# Altium schematic sheet SCM20260D-Connectors2.SchDoc(SCM20260D-Connectors2)
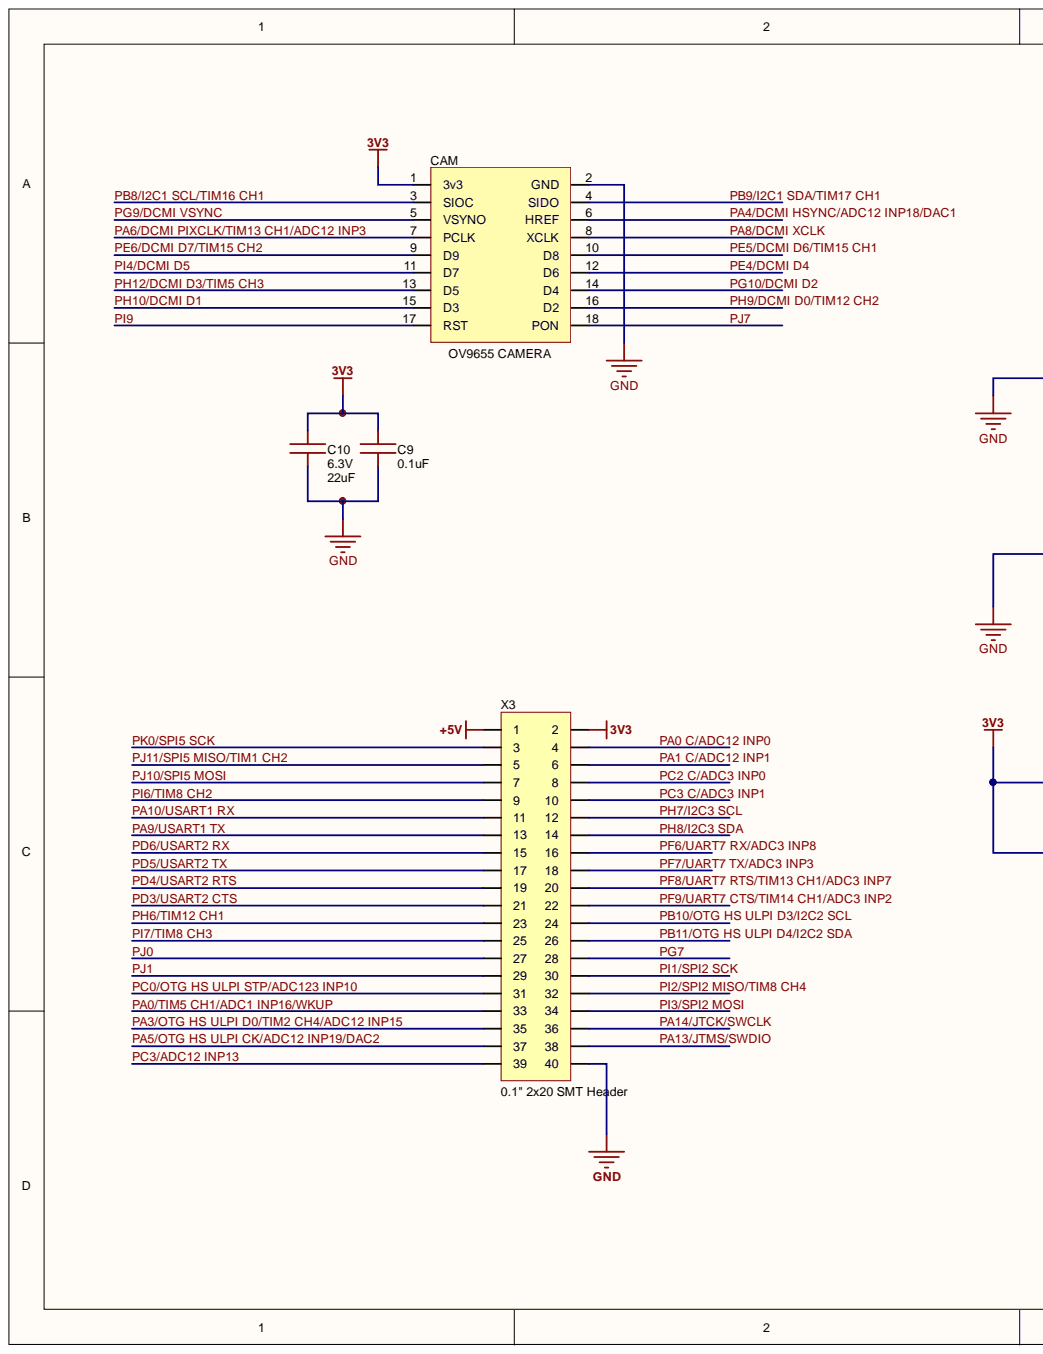
[diagram p0: SCM20260D-Connectors2.SchDoc, left]
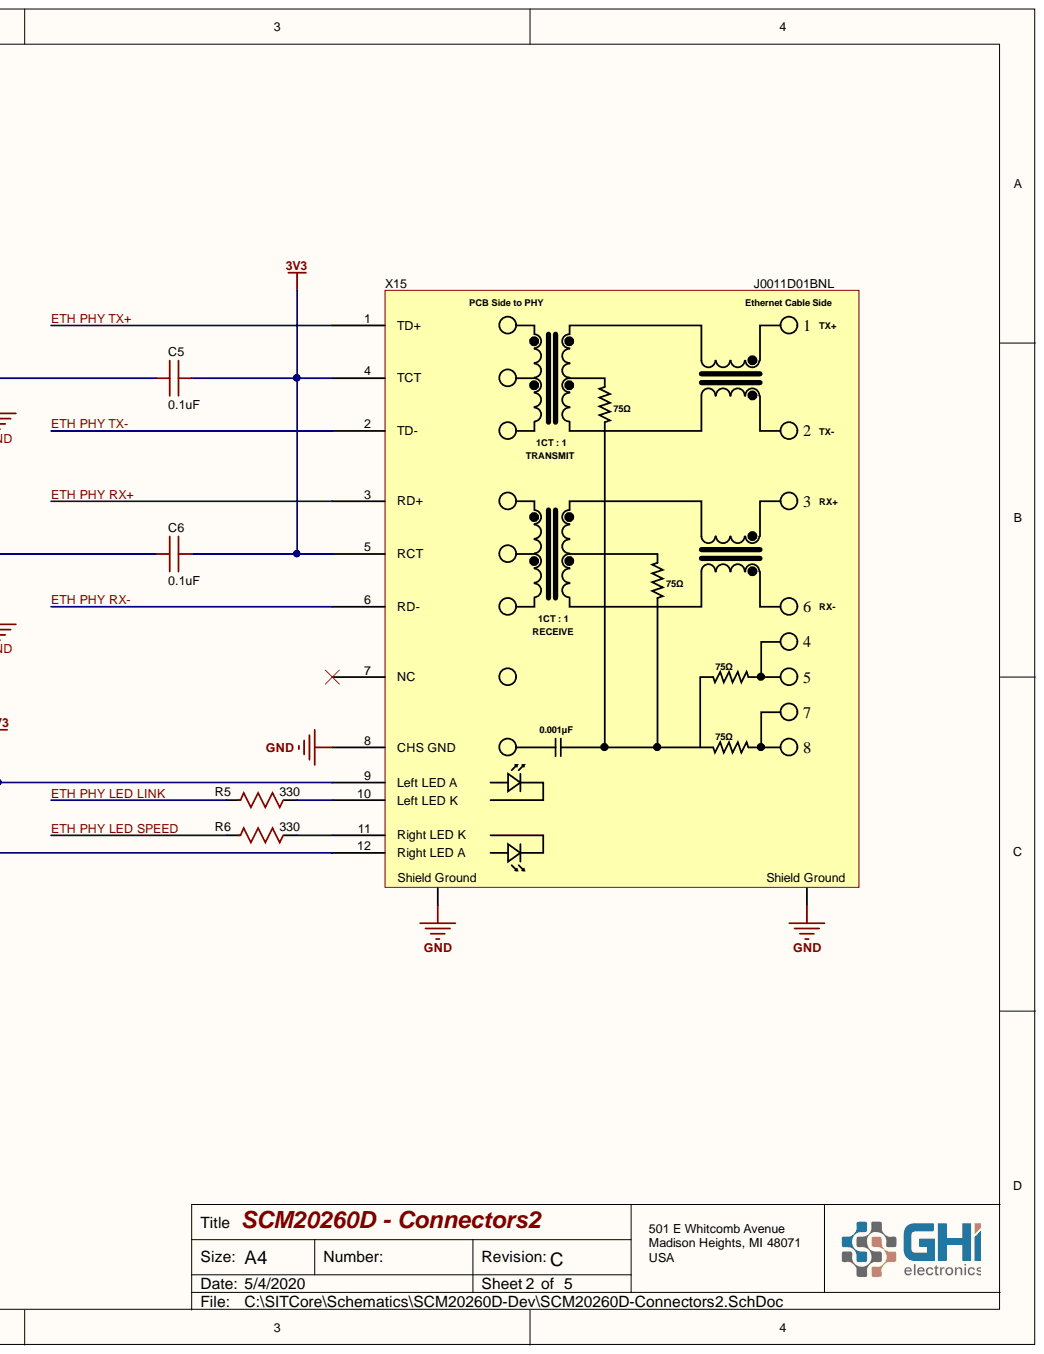
[diagram p1: SCM20260D-Connectors2.SchDoc, right]

## Parts
C5  value 0.1uF  [C0603 (1608 Metric)]  (hidden comment: 0603 Cap 0.1uF)
C6  value 0.1uF  [C0603 (1608 Metric)]  (hidden comment: 0603 Cap 0.1uF)
C9  value 0.1uF  [C0603 (1608 Metric)]  (hidden comment: 0603 Cap 0.1uF)
C10  value 22uF  Voltage: 6.3V  [C0805]  (hidden comment: 0805 Cap 22uF)
CAM  OV9655 CAMERA  value OV9655  [2X09]
R5  value 330  [R0603 (1608 Metric)]  (hidden comment: 0603 330)
R6  value 330  [R0603 (1608 Metric)]  (hidden comment: 0603 330)
X3  0.1" 2x20 SMT Header  [SMT 0.1" 2x20]
X15  J0011D01BNL  [J0A]

## Nets
3V3: C5.2 C6.2 C9.2 C10.2 CAM.1(3v3) X3.2 X15.4(TCT) X15.5(RCT) X15.9(Left_LED_A) X15.12(Right_LED_A)
+5V: X3.1
GND: C5.1 C6.1 C9.1 C10.1 CAM.2(GND) X3.40 X15.8(CHS_GND) X15.SG1 X15.SG2
ETH PHY LED LINK: R5.1
ETH PHY LED SPEED: R6.1
ETH PHY RX+: X15.3(RD+)
ETH PHY RX-: X15.6(RD-)
ETH PHY TX+: X15.1(TD+)
ETH PHY TX-: X15.2(TD-)
PA0 C/ADC12 INP0: X3.4
PA0/TIM5 CH1/ADC1 INP16/WKUP: X3.33
PA1 C/ADC12 INP1: X3.6
PA3/OTG HS ULPI D0/TIM2 CH4/ADC12 INP15: X3.35
PA4/DCMI HSYNC/ADC12 INP18/DAC1: CAM.6(HREF)
PA5/OTG HS ULPI CK/ADC12 INP19/DAC2: X3.37
PA6/DCMI PIXCLK/TIM13 CH1/ADC12 INP3: CAM.7(PCLK)
PA8/DCMI XCLK: CAM.8(XCLK)
PA9/USART1 TX: X3.13
PA10/USART1 RX: X3.11
PA13/JTMS/SWDIO: X3.38
PA14/JTCK/SWCLK: X3.36
PB8/I2C1 SCL/TIM16 CH1: CAM.3(SIOC)
PB9/I2C1 SDA/TIM17 CH1: CAM.4(SIDO)
PB10/OTG HS ULPI D3/I2C2 SCL: X3.24
PB11/OTG HS ULPI D4/I2C2 SDA: X3.26
PC0/OTG HS ULPI STP/ADC123 INP10: X3.31
PC2 C/ADC3 INP0: X3.8
PC3 C/ADC3 INP1: X3.10
PC3/ADC12 INP13: X3.39
PD3/USART2 CTS: X3.21
PD4/USART2 RTS: X3.19
PD5/USART2 TX: X3.17
PD6/USART2 RX: X3.15
PE4/DCMI D4: CAM.12(D6)
PE5/DCMI D6/TIM15 CH1: CAM.10(D8)
PE6/DCMI D7/TIM15 CH2: CAM.9(D9)
PF6/UART7 RX/ADC3 INP8: X3.16
PF7/UART7 TX/ADC3 INP3: X3.18
PF8/UART7 RTS/TIM13 CH1/ADC3 INP7: X3.20
PF9/UART7 CTS/TIM14 CH1/ADC3 INP2: X3.22
PG7: X3.28
PG9/DCMI VSYNC: CAM.5(VSYNO)
PG10/DCMI D2: CAM.14(D4)
PH6/TIM12 CH1: X3.23
PH7/I2C3 SCL: X3.12
PH8/I2C3 SDA: X3.14
PH9/DCMI D0/TIM12 CH2: CAM.16(D2)
PH10/DCMI D1: CAM.15(D3)
PH12/DCMI D3/TIM5 CH3: CAM.13(D5)
PI1/SPI2 SCK: X3.30
PI2/SPI2 MISO/TIM8 CH4: X3.32
PI3/SPI2 MOSI: X3.34
PI4/DCMI D5: CAM.11(D7)
PI6/TIM8 CH2: X3.9
PI7/TIM8 CH3: X3.25
PI9: CAM.17(RST)
PJ0: X3.27
PJ1: X3.29
PJ7: CAM.18(PON)
PJ10/SPI5 MOSI: X3.7
PJ11/SPI5 MISO/TIM1 CH2: X3.5
PK0/SPI5 SCK: X3.3
NetR5_2: R5.2 X15.10(Left_LED_K)
NetR6_2: R6.2 X15.11(Right_LED_K)

Not connected: X15 7(NC)

## Library data of the parts
C5 C6 C9 = 0603 Cap 0.1uF: 0.1uF ±5% 50V X7R 0603 Multilayer Ceramic Capacitors MLCC - SMD/SMT RoHS; Mfr.Part #: CL10B104JB8NNNC; Voltage: 50V; Tolerance: ±5%; Package: 0603; LCSC Part #: C24452; Digikey: 1276-1033-1-ND; Component Kind: Standard; Category: Ceramic; Capacitance: 0.1uF; Library Name: GHI-Passives.SchLib
C10 = 0805 Cap 22uF: 22uF ±20% 6.3V X5R 0805 Multilayer Ceramic Capacitors MLCC - SMD/SMT RoHS; Mfr.Part #: CL21A226MQCLRNC; Tolerance: ±20%; Package: 0805; LCSC Part #: C307530; Component Kind: Standard; Category: Ceramic; Capacitance: 22uF; Library Name: GHI-Passives.SchLib
R5 R6 = 0603 Res 330: 330 ±5% 1/10W ±100ppm/℃ 0603 Chip Resistor - Surface Mount RoHS; Mfr.Part #: WR06X331 JTL; Manufacturer: Walsin Tech Corp; Voltage Rating: *V; Resistance Tolerance: ±5%; Resistance: 330; Power Rating: 1/10W; Package: 0603; LCSC Part #: C384242; Component Kind: Standard; Library Name: GHI-Passives.SchLib
X3 = 2x20 Pin 0.1" SMT: Value: 2x20 Pin 0.1" SMT; Description: 2x20 Pin 0.1" SMT; Component Kind: Standard; Library Name: GHI.SchLib
X15 = J0011D01BNL: PulseJack RJ45 J0 Series Ethernet Connector; Value: J0011D01BNL; Component Kind: Standard; Library Name: GHI Connectors.SchLib
CAM = OV9655 CAMERA: PartNo: OV9655; Component Kind: Standard; Library Name: GHI.SchLib
